# Altium schematic sheet Memory.SCHDOC(Memory)
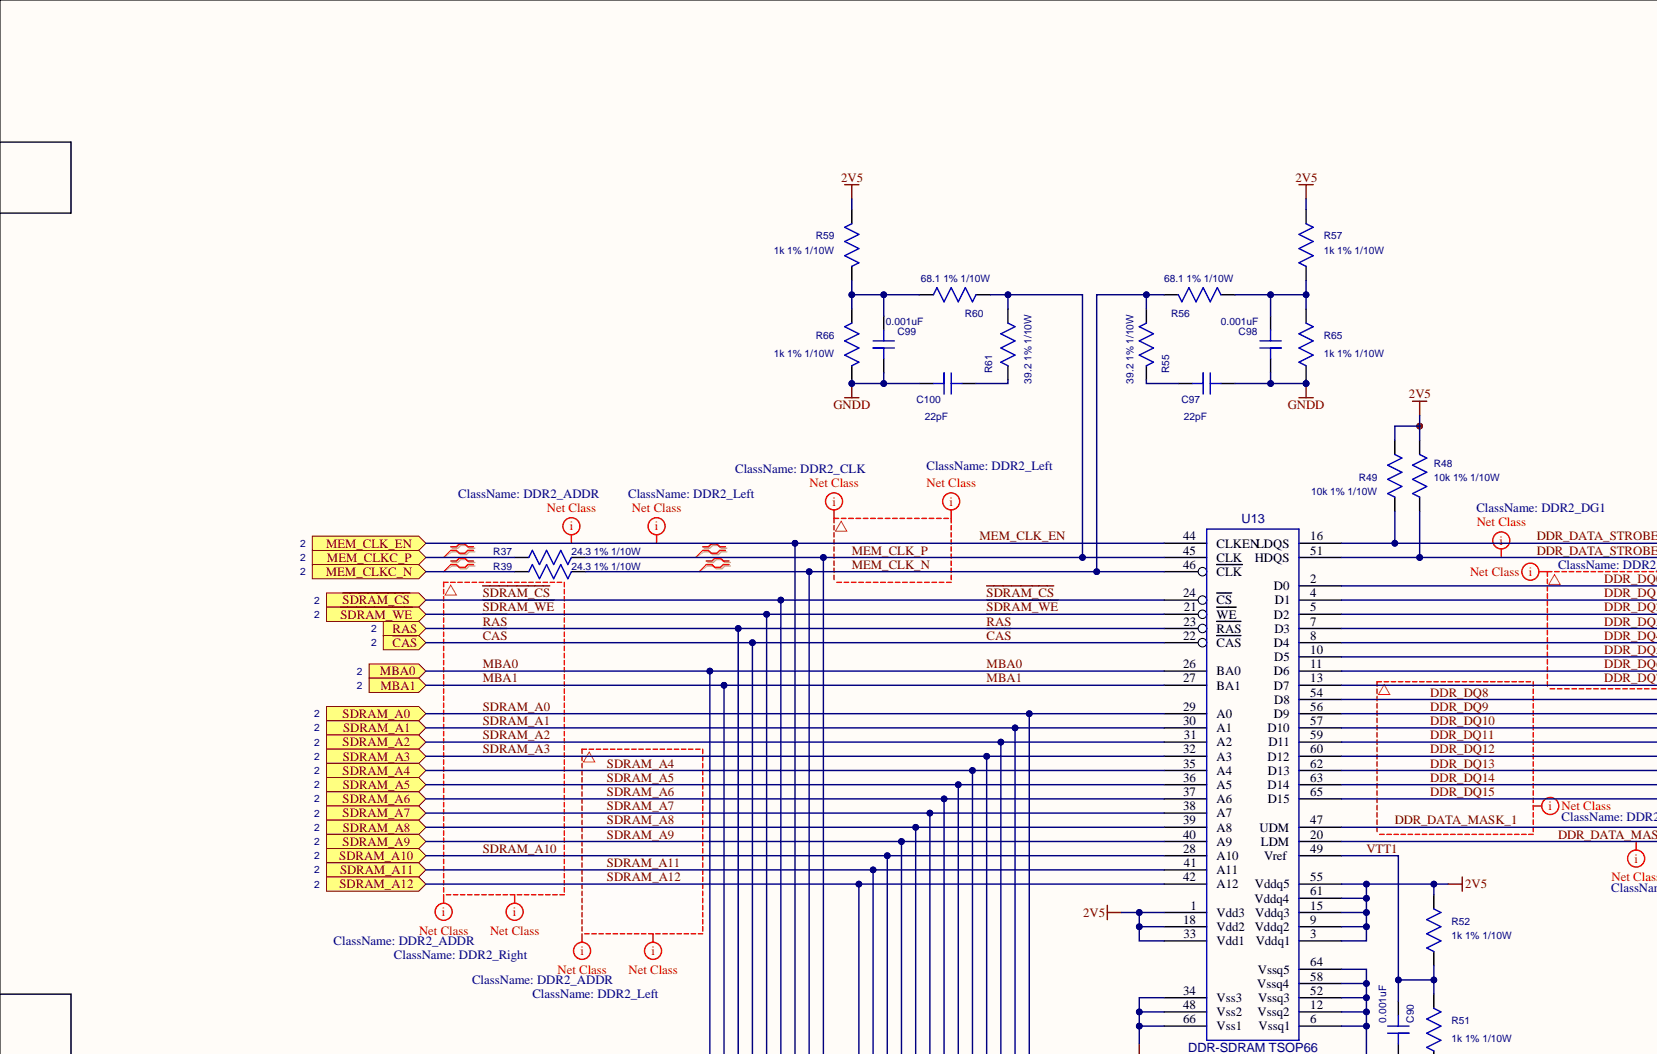
[diagram p0: Memory.SCHDOC, top left]
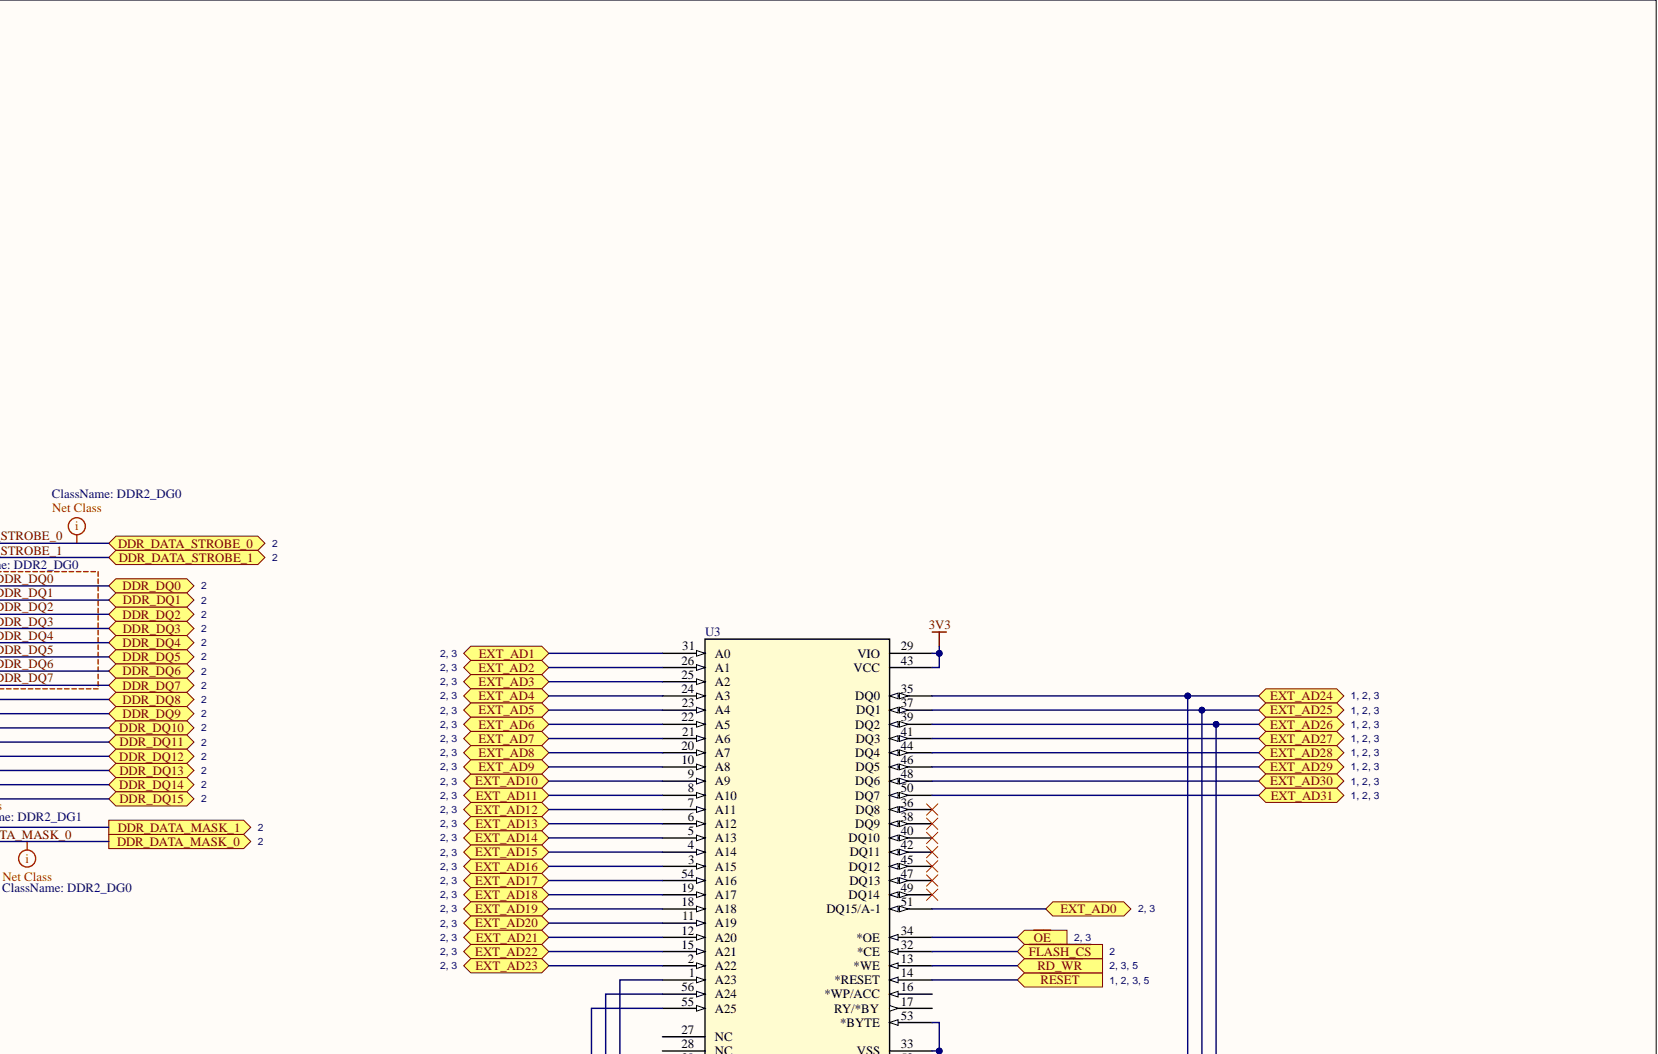
[diagram p1: Memory.SCHDOC, top right]
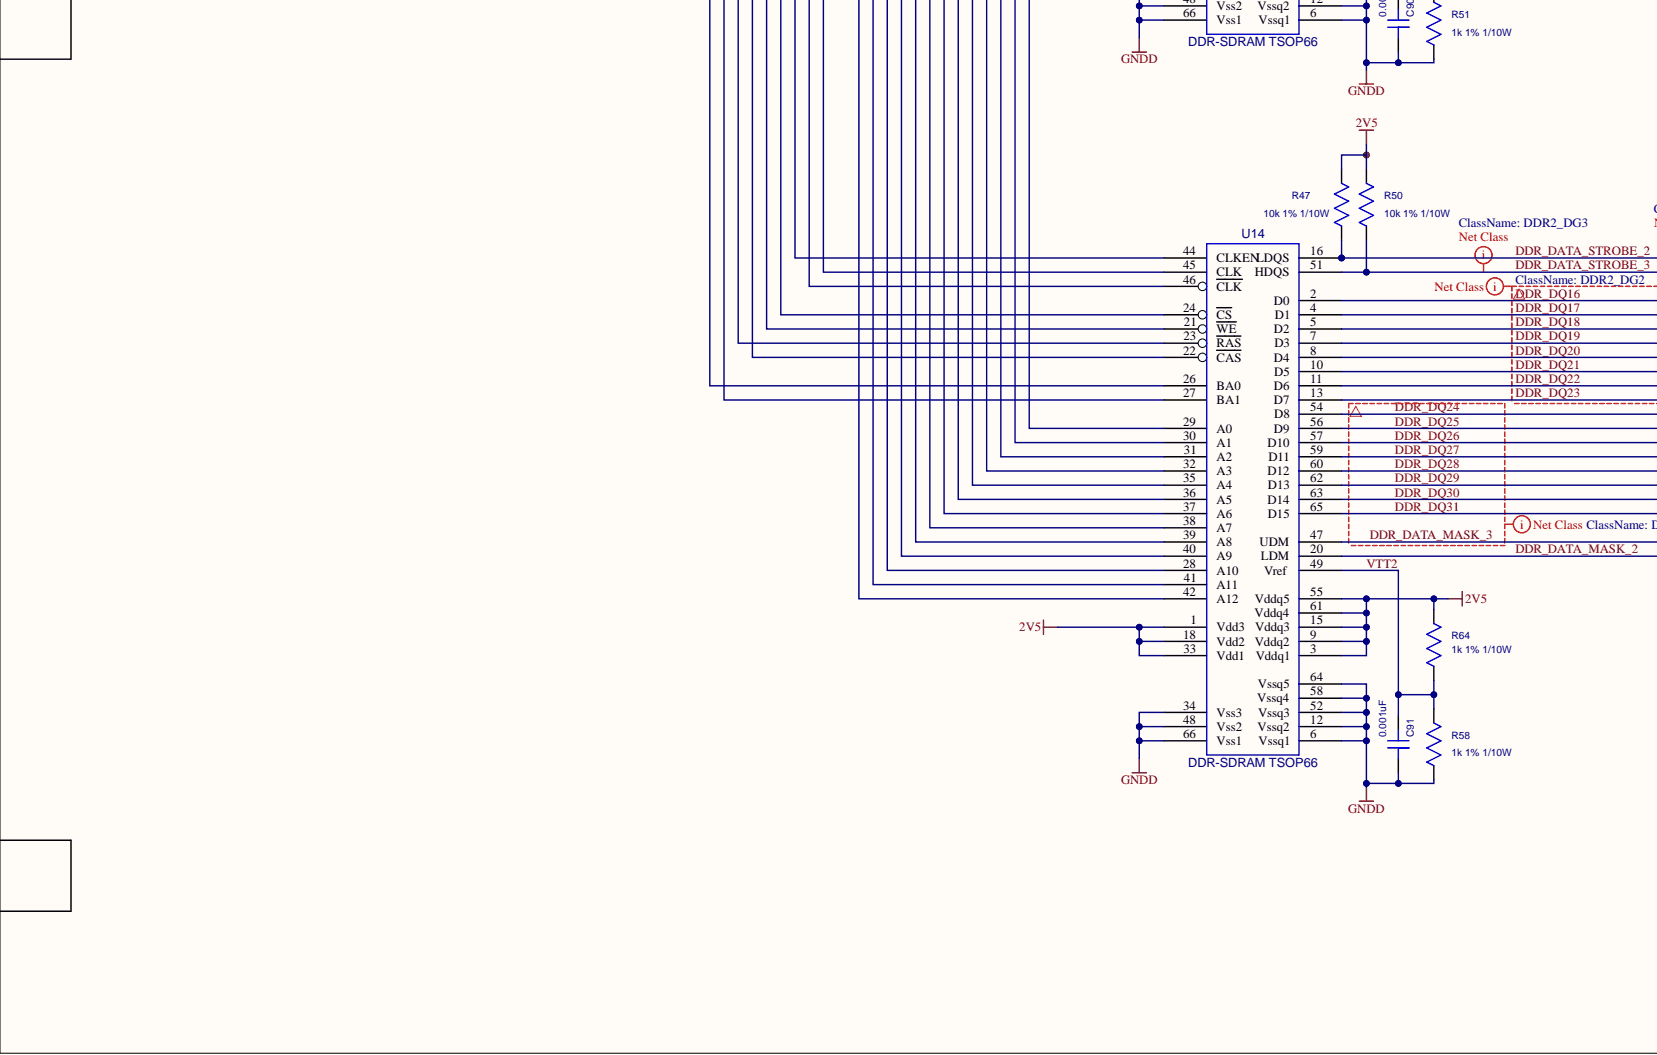
[diagram p2: Memory.SCHDOC, bottom left]
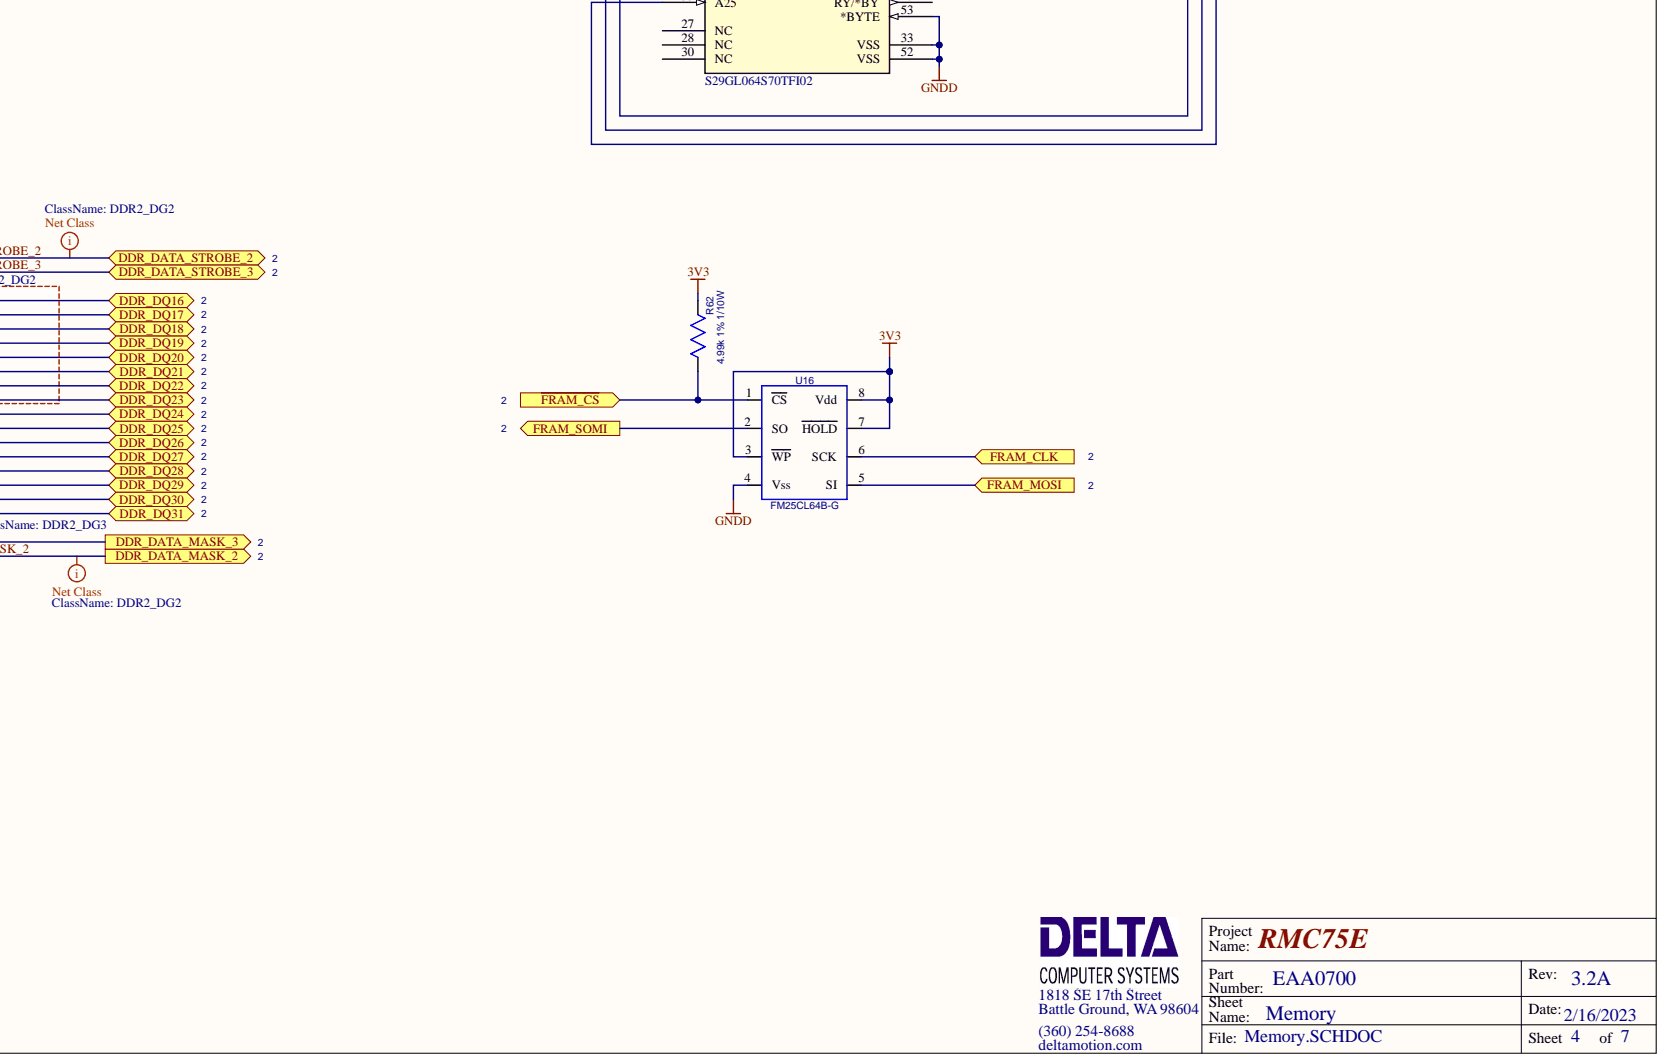
[diagram p3: Memory.SCHDOC, bottom right]

## Parts
C90  0.001uF  [CC0603]
C91  0.001uF  [CC0603]
C97  22pF  [CC0603]
C98  0.001uF  [CC0603]
C99  0.001uF  [CC0603]
C100  22pF  [CC0603]
R37  24.3 1% 1/10W  [CC0603]
R39  24.3 1% 1/10W  [CC0603]
R47  10k 1% 1/10W  [CC0603]
R48  10k 1% 1/10W  [CC0603]
R49  10k 1% 1/10W  [CC0603]
R50  10k 1% 1/10W  [CC0603]
R51  1k 1% 1/10W  [CC0603]
R52  1k 1% 1/10W  [CC0603]
R55  39.2 1% 1/10W  [CC0603]
R56  68.1 1% 1/10W  [CC0603]
R57  1k 1% 1/10W  [CC0603]
R58  1k 1% 1/10W  [CC0603]
R59  1k 1% 1/10W  [CC0603]
R60  68.1 1% 1/10W  [CC0603]
R61  39.2 1% 1/10W  [CC0603]
R62  4.99k 1% 1/10W  [CC0603]
R64  1k 1% 1/10W  [CC0603]
R65  1k 1% 1/10W  [CC0603]
R66  1k 1% 1/10W  [CC0603]
U3  S29GL064S70TFI02  [TSOP-56M]
U13  DDR-SDRAM TSOP66  [TSOP13X60-66]
U14  DDR-SDRAM TSOP66  [TSOP13X60-66]
U16  FM25CL64B-G  [SO8]

## Ports
DDR_DATA_MASK_0, DDR_DATA_MASK_1, DDR_DATA_MASK_2, DDR_DATA_MASK_3, DDR_DATA_STROBE_0, DDR_DATA_STROBE_1, DDR_DATA_STROBE_2, DDR_DATA_STROBE_3, DDR_DQ0, DDR_DQ1, DDR_DQ2, DDR_DQ3, DDR_DQ4, DDR_DQ5, DDR_DQ6, DDR_DQ7, DDR_DQ8, DDR_DQ9, DDR_DQ10, DDR_DQ11, DDR_DQ12, DDR_DQ13, DDR_DQ14, DDR_DQ15, DDR_DQ16, DDR_DQ17, DDR_DQ18, DDR_DQ19, DDR_DQ20, DDR_DQ21, DDR_DQ22, DDR_DQ23, DDR_DQ24, DDR_DQ25, DDR_DQ26, DDR_DQ27, DDR_DQ28, DDR_DQ29, DDR_DQ30, DDR_DQ31, EXT_AD0, EXT_AD1, EXT_AD2, EXT_AD3, EXT_AD4, EXT_AD5, EXT_AD6, EXT_AD7, EXT_AD8, EXT_AD9, EXT_AD10, EXT_AD11, EXT_AD12, EXT_AD13, EXT_AD14, EXT_AD15, EXT_AD16, EXT_AD17, EXT_AD18, EXT_AD19, EXT_AD20, EXT_AD21, EXT_AD22, EXT_AD23, EXT_AD24, EXT_AD25, EXT_AD26, EXT_AD27, EXT_AD28, EXT_AD29, EXT_AD30, EXT_AD31, FRAM_CLK, FRAM_MOSI, FRAM_SOMI, MBA0, MBA1, MEM_CLK_EN, MEM_CLKC_N, MEM_CLKC_P, RD_~{WR}, SDRAM_A0, SDRAM_A1, SDRAM_A2, SDRAM_A3, SDRAM_A4, SDRAM_A5, SDRAM_A6, SDRAM_A7, SDRAM_A8, SDRAM_A9, SDRAM_A10, SDRAM_A11, SDRAM_A12, ~{CAS}, ~{FLASH_CS}, ~{FRAM_CS}, ~{OE}, ~{RAS}, ~{RESET}, ~{SDRAM_CS}, ~{SDRAM_WE}

## Nets
2V5: R47.2 R48.2 R49.2 R50.2 R52.2 R57.2 R59.2 R64.2 U13.1(Vdd3) U13.3(Vddq1) U13.9(Vddq2) U13.15(Vddq3) U13.18(Vdd2) U13.33(Vdd1) U13.55(Vddq5) U13.61(Vddq4) U14.1(Vdd3) U14.3(Vddq1) U14.9(Vddq2) U14.15(Vddq3) U14.18(Vdd2) U14.33(Vdd1) U14.55(Vddq5) U14.61(Vddq4)
3V3: R62.2 U3.29(VIO) U3.43(VCC) U16.3(WP) U16.7(HOLD) U16.8(Vdd)
GNDD: C90.2 C91.2 C97.1 C98.2 C99.2 C100.2 R51.1 R58.1 R65.1 R66.1 U3.33(VSS) U3.52(VSS) U3.53(*BYTE) U13.6(Vssq1) U13.12(Vssq2) U13.34(Vss3) U13.48(Vss2) U13.52(Vssq3) U13.58(Vssq4) U13.64(Vssq5) U13.66(Vss1) U14.6(Vssq1) U14.12(Vssq2) U14.34(Vss3) U14.48(Vss2) U14.52(Vssq3) U14.58(Vssq4) U14.64(Vssq5) U14.66(Vss1) U16.4(Vss)
~{CAS}: U13.22(CAS) U14.22(CAS) ; port ~{CAS}
DDR_DATA_MASK_0: U13.20(LDM) ; port DDR_DATA_MASK_0
DDR_DATA_MASK_1: U13.47(UDM) ; port DDR_DATA_MASK_1
DDR_DATA_MASK_2: U14.20(LDM) ; port DDR_DATA_MASK_2
DDR_DATA_MASK_3: U14.47(UDM) ; port DDR_DATA_MASK_3
DDR_DATA_STROBE_0: R49.1 U13.16(LDQS) ; port DDR_DATA_STROBE_0
DDR_DATA_STROBE_1: R48.1 U13.51(HDQS) ; port DDR_DATA_STROBE_1
DDR_DATA_STROBE_2: R47.1 U14.16(LDQS) ; port DDR_DATA_STROBE_2
DDR_DATA_STROBE_3: R50.1 U14.51(HDQS) ; port DDR_DATA_STROBE_3
DDR_DQ0: U13.2(D0) ; port DDR_DQ0
DDR_DQ1: U13.4(D1) ; port DDR_DQ1
DDR_DQ2: U13.5(D2) ; port DDR_DQ2
DDR_DQ3: U13.7(D3) ; port DDR_DQ3
DDR_DQ4: U13.8(D4) ; port DDR_DQ4
DDR_DQ5: U13.10(D5) ; port DDR_DQ5
DDR_DQ6: U13.11(D6) ; port DDR_DQ6
DDR_DQ7: U13.13(D7) ; port DDR_DQ7
DDR_DQ8: U13.54(D8) ; port DDR_DQ8
DDR_DQ9: U13.56(D9) ; port DDR_DQ9
DDR_DQ10: U13.57(D10) ; port DDR_DQ10
DDR_DQ11: U13.59(D11) ; port DDR_DQ11
DDR_DQ12: U13.60(D12) ; port DDR_DQ12
DDR_DQ13: U13.62(D13) ; port DDR_DQ13
DDR_DQ14: U13.63(D14) ; port DDR_DQ14
DDR_DQ15: U13.65(D15) ; port DDR_DQ15
DDR_DQ16: U14.2(D0) ; port DDR_DQ16
DDR_DQ17: U14.4(D1) ; port DDR_DQ17
DDR_DQ18: U14.5(D2) ; port DDR_DQ18
DDR_DQ19: U14.7(D3) ; port DDR_DQ19
DDR_DQ20: U14.8(D4) ; port DDR_DQ20
DDR_DQ21: U14.10(D5) ; port DDR_DQ21
DDR_DQ22: U14.11(D6) ; port DDR_DQ22
DDR_DQ23: U14.13(D7) ; port DDR_DQ23
DDR_DQ24: U14.54(D8) ; port DDR_DQ24
DDR_DQ25: U14.56(D9) ; port DDR_DQ25
DDR_DQ26: U14.57(D10) ; port DDR_DQ26
DDR_DQ27: U14.59(D11) ; port DDR_DQ27
DDR_DQ28: U14.60(D12) ; port DDR_DQ28
DDR_DQ29: U14.62(D13) ; port DDR_DQ29
DDR_DQ30: U14.63(D14) ; port DDR_DQ30
DDR_DQ31: U14.65(D15) ; port DDR_DQ31
EXT_AD0: U3.51(DQ15/A-1) ; port EXT_AD0
EXT_AD1: U3.31(A0) ; port EXT_AD1
EXT_AD2: U3.26(A1) ; port EXT_AD2
EXT_AD3: U3.25(A2) ; port EXT_AD3
EXT_AD4: U3.24(A3) ; port EXT_AD4
EXT_AD5: U3.23(A4) ; port EXT_AD5
EXT_AD6: U3.22(A5) ; port EXT_AD6
EXT_AD7: U3.21(A6) ; port EXT_AD7
EXT_AD8: U3.20(A7) ; port EXT_AD8
EXT_AD9: U3.10(A8) ; port EXT_AD9
EXT_AD10: U3.9(A9) ; port EXT_AD10
EXT_AD11: U3.8(A10) ; port EXT_AD11
EXT_AD12: U3.7(A11) ; port EXT_AD12
EXT_AD13: U3.6(A12) ; port EXT_AD13
EXT_AD14: U3.5(A13) ; port EXT_AD14
EXT_AD15: U3.4(A14) ; port EXT_AD15
EXT_AD16: U3.3(A15) ; port EXT_AD16
EXT_AD17: U3.54(A16) ; port EXT_AD17
EXT_AD18: U3.19(A17) ; port EXT_AD18
EXT_AD19: U3.18(A18) ; port EXT_AD19
EXT_AD20: U3.11(A19) ; port EXT_AD20
EXT_AD21: U3.12(A20) ; port EXT_AD21
EXT_AD22: U3.15(A21) ; port EXT_AD22
EXT_AD23: U3.2(A22) ; port EXT_AD23
EXT_AD24: U3.1(A23) U3.35(DQ0) ; port EXT_AD24
EXT_AD25: U3.37(DQ1) U3.56(A24) ; port EXT_AD25
EXT_AD26: U3.39(DQ2) U3.55(A25) ; port EXT_AD26
EXT_AD27: U3.41(DQ3) ; port EXT_AD27
EXT_AD28: U3.44(DQ4) ; port EXT_AD28
EXT_AD29: U3.46(DQ5) ; port EXT_AD29
EXT_AD30: U3.48(DQ6) ; port EXT_AD30
EXT_AD31: U3.50(DQ7) ; port EXT_AD31
~{FLASH_CS}: U3.32(*CE) ; port ~{FLASH_CS}
~{FRAM_CS}: R62.1 U16.1(CS) ; port ~{FRAM_CS}
FRAM_CLK: U16.6(SCK) ; port FRAM_CLK
FRAM_MOSI: U16.5(SI) ; port FRAM_MOSI
FRAM_SOMI: U16.2(SO) ; port FRAM_SOMI
MBA0: U13.26(BA0) U14.26(BA0) ; port MBA0
MBA1: U13.27(BA1) U14.27(BA1) ; port MBA1
MEM_CLK_EN: U13.44(CLKEN) U14.44(CLKEN) ; port MEM_CLK_EN
MEM_CLK_N: R39.2 R55.2 R56.1 U13.46(CLK) U14.46(CLK)
MEM_CLK_P: R37.2 R60.1 R61.2 U13.45(CLK) U14.45(CLK)
MEM_CLKC_N: R39.1 ; port MEM_CLKC_N
MEM_CLKC_P: R37.1 ; port MEM_CLKC_P
~{OE}: U3.34(*OE) ; port ~{OE}
~{RAS}: U13.23(RAS) U14.23(RAS) ; port ~{RAS}
~{RESET}: U3.14(*RESET) ; port ~{RESET}
RD_~{WR}: U3.13(*WE) ; port RD_~{WR}
~{SDRAM_CS}: U13.24(CS) U14.24(CS) ; port ~{SDRAM_CS}
~{SDRAM_WE}: U13.21(WE) U14.21(WE) ; port ~{SDRAM_WE}
SDRAM_A0: U13.29(A0) U14.29(A0) ; port SDRAM_A0
SDRAM_A1: U13.30(A1) U14.30(A1) ; port SDRAM_A1
SDRAM_A2: U13.31(A2) U14.31(A2) ; port SDRAM_A2
SDRAM_A3: U13.32(A3) U14.32(A3) ; port SDRAM_A3
SDRAM_A4: U13.35(A4) U14.35(A4) ; port SDRAM_A4
SDRAM_A5: U13.36(A5) U14.36(A5) ; port SDRAM_A5
SDRAM_A6: U13.37(A6) U14.37(A6) ; port SDRAM_A6
SDRAM_A7: U13.38(A7) U14.38(A7) ; port SDRAM_A7
SDRAM_A8: U13.39(A8) U14.39(A8) ; port SDRAM_A8
SDRAM_A9: U13.40(A9) U14.40(A9) ; port SDRAM_A9
SDRAM_A10: U13.28(A10) U14.28(A10) ; port SDRAM_A10
SDRAM_A11: U13.41(A11) U14.41(A11) ; port SDRAM_A11
SDRAM_A12: U13.42(A12) U14.42(A12) ; port SDRAM_A12
VTT1: C90.1 R51.2 R52.1 U13.49(Vref)
VTT2: C91.1 R58.2 R64.1 U14.49(Vref)
NetC97_2: C97.2 R55.1
NetC98_1: C98.1 R56.2 R57.1 R65.2
NetC99_1: C99.1 R59.1 R60.2 R66.2
NetC100_1: C100.1 R61.1

Not connected: U3 16(*WP/ACC) 17(RY/*BY) 27(NC) 28(NC) 30(NC) 36(DQ8) 38(DQ9) 40(DQ10) 42(DQ11) 45(DQ12) 47(DQ13) 49(DQ14)

## Library data of the parts
C90 C91 C98 C99 = CAPNP_SMT0603: Cap Cer 1000pF 50V 10% 0603 X7R; Manufacturer: Venkel; PartNumber: C0603X7R500-102KNE; Component Kind: Standard; Value: 0.001uF
C97 C100 = CAPNP_SMT0603: Cap Cer 22pF 50V 5% 0603 C0G; Manufacturer: Venkel; PartNumber: C0603C0G500-220JNE; Component Kind: Standard; Value: 22pF
R37 R39 = RES_GEN_SMT0603: Res 24.3 1% 1/10W 100ppm 0603; Manufacturer: Venkel; PartNumber: CR0603-10W-24R3FT; Component Kind: Standard; Value: 24.3 1% 1/10W
R47-R50 = RES_GEN_SMT0603: Res 10k 1% 1/10W 100ppm 0603; Manufacturer: Venkel; PartNumber: CR0603-10W-1002FT; Component Kind: Standard; Value: 10k 1% 1/10W
R51 R52 R57-R59 R64-R66 = RES_GEN_SMT0603: Res 1k 1% 1/10W 100ppm 0603; Manufacturer: Venkel; PartNumber: CR0603-10W-1001FT; Component Kind: Standard; Value: 1k 1% 1/10W
R55 R61 = RES_GEN_SMT0603: Res 39.2 1% 1/10W 100ppm 0603; Manufacturer: Venkel; PartNumber: CR0603-10W-39R2FT; Component Kind: Standard; Value: 39.2 1% 1/10W
R56 R60 = RES_GEN_SMT0603: Res 68.1 1% 1/10W 100ppm 0603; Manufacturer: Venkel; PartNumber: CR0603-10W-68R1FT; Component Kind: Standard; Value: 68.1 1% 1/10W
R62 = RES_GEN_SMT0603: Res 4.99k 1% 1/10W 100ppm 0603; Manufacturer: Venkel; PartNumber: CR0603-10W-4991FT; Component Kind: Standard; Value: 4.99k 1% 1/10W
U3 = S29GL064S70TFI02: FLASH 256Mbit 90ns 56TSOP; Manufacturer: Cypress; PartNumber: S29GL064S70TFI020; Component Kind: Standard; Library Name: Memory.IntLib; Type: Type; Value: Value
U13 U14 = DDR-SDRAM TSOP66: DDR SDRAM 256Mbit 66TSOP; Manufacturer: Micron; PartNumber: MT46V16M16P-5B:M; Component Kind: Standard
U16 = FM25CL64-S: FRAM 64Kbit 16MHz SPI 8SOIC; Manufacturer: Ramtron; Component Kind: Standard; PartNumber: FM25CL64B-G
